# Altium schematic sheet switch.SchDoc
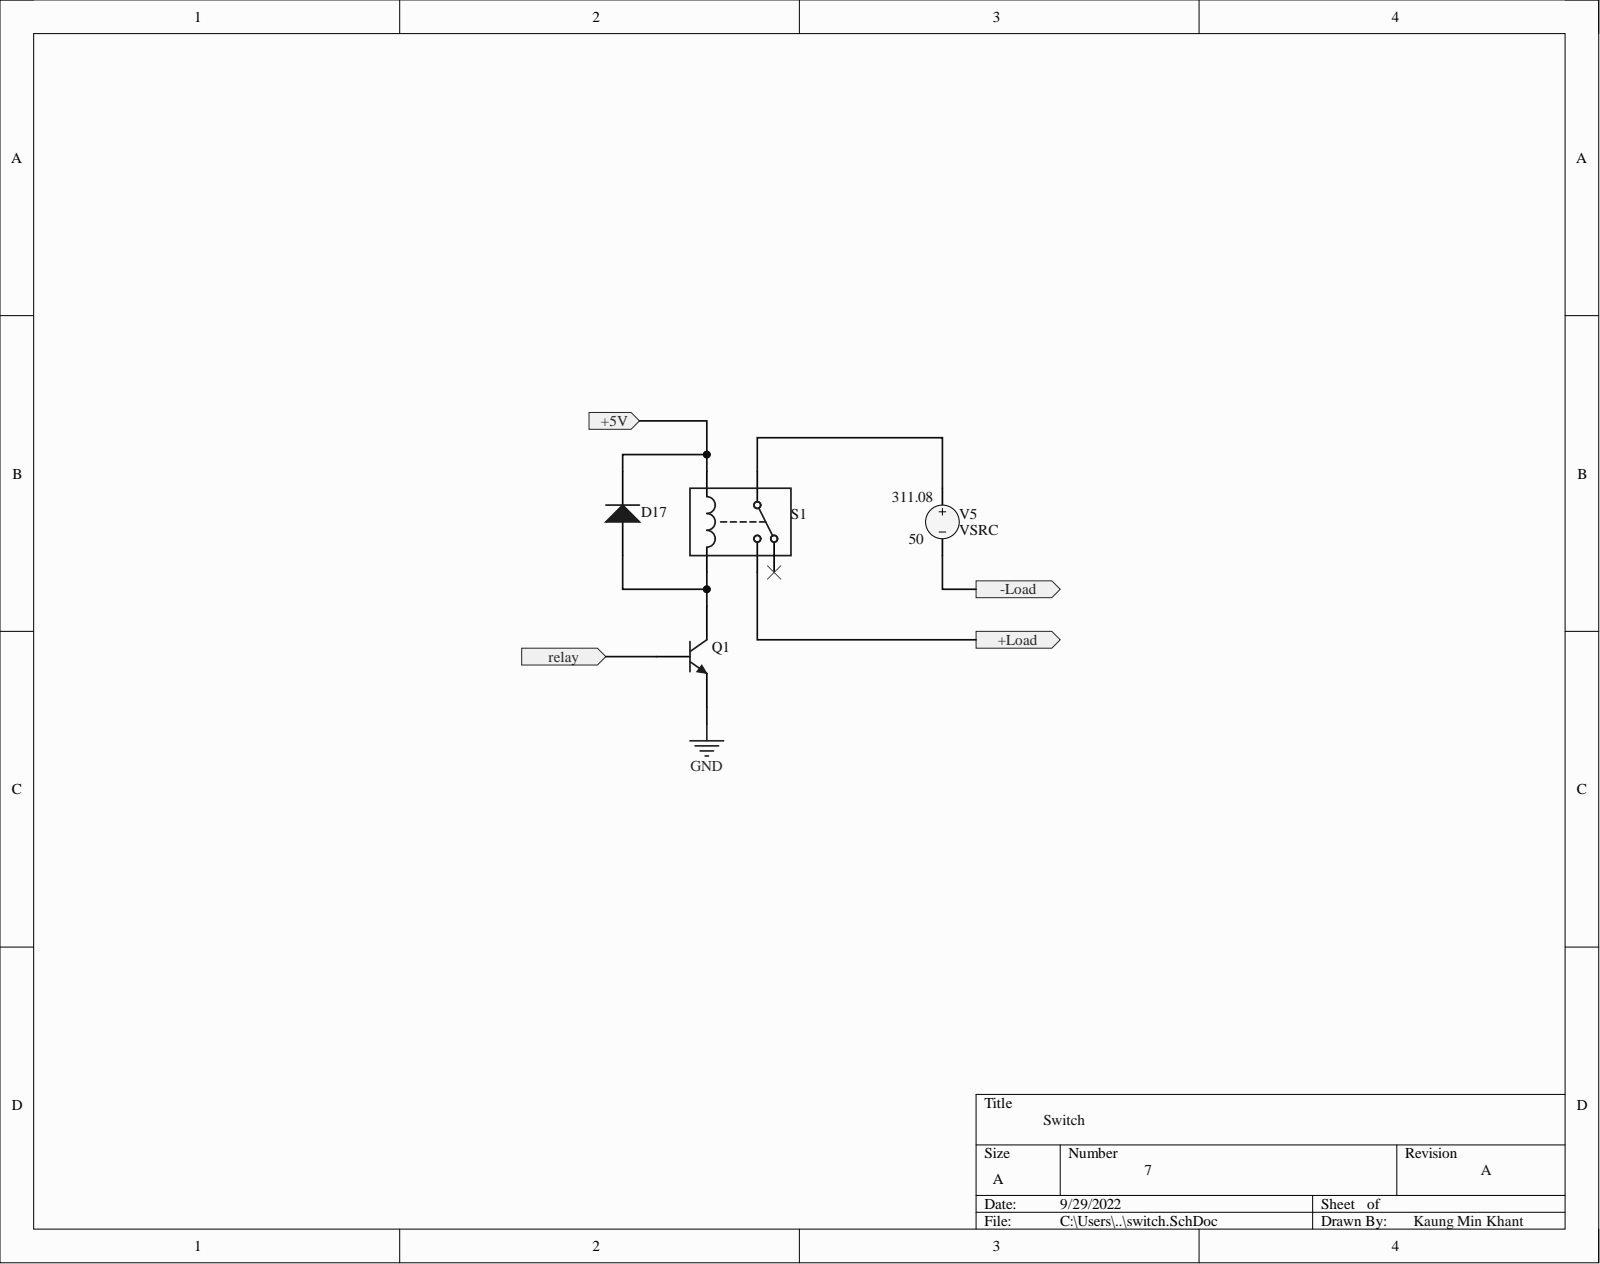
[diagram p0: switch.SchDoc]

## Parts
D17  Diode  (value not drawn)
Q1  Bipolar  NPN 3 Pins  Transistor VBIC Model  (value not drawn)
S1  Single-Pole, Double-Throw Relay  (value not drawn)
V5  VSRC  Amplitude: 311.08

## Ports
+5V, +Load, -Load, relay

## Nets
GND: Q1.3
+5V: D17.2 S1.4 ; port +5V
relay: Q1.2 ; port relay
NetD17_1: D17.1 Q1.1 S1.5
NetS1_1: S1.1 V5.1
NetS1_2: S1.2
NetV5_2: V5.2

Not connected: S1 3

## Library data of the parts
D17 = Diode: AF: 1; BV: 0; BV_MAX: 1e99; EG: 1.11; FC: 0.5; IBV: 0.001; IS: 1e-14; MJSW: 0.33; NTUN: 30; SimulationGenericComponent: True; TNOM: 27; XTI: 3; CJO: 0; CJP: 0; Component Kind: Standard; CTA: 0; CTP: 0; Description: Diode; FCS: 0.5; FV_MAX: 1e99; IKF: 0; IKR: 0; ISR: 1e-14; JSW: 0; JTUN: 0; JTUNSW: 0; KEG: 1; KF: 0; LEVEL: 1; Library Name: Simulation Generic Components.IntLib; N: 1; NBV: 1; NR: 1; NS: 1; PHP: 1; RS: 0; Simulation: diode; TCV: 0; TLEV: 0; TLEVC: 0; TM1: 0; TM2: 0; TPB: 0; TPHP: 0; TRS: 0; TRS2: 0; TT: 0; TTT1: 0; TTT2: 0; VJ: 1; XTITUN: 3
Q1 = BJT NPN VBIC: AFN: 1; AJC: -0.5; ART: 0.1; AVC1: 0; EA: 1.12; FC: 0.9; IBBE: 1e-06; IBCI: 1e-16; IBEI: 1e-18; MC: 0.33; NCN: 2; NKF: 0.5; PC: 0.75; SimulationGenericComponent: True; TNOM: 27; VERS: 1.2; XII: 3; AJE: -0.5; AJS: -0.5; AVC2: 0; BFN: 1; CBCO: 0; CBEO: 0; CCSO: 0; CJC: 0; CJCP: 0; CJE: 0; CJEP: 0; Component Kind: Standard; CTH: 0; DEAR: 0; Description: Bipolar  NPN 3 Pins  Transistor VBIC Model; EAIC: 1.12; EAIE: 1.12; EAIS: 1.12; EANC: 1.12; EANE: 1.12; EANS: 1.12; EAP: 1.12; EBBE: 0; GAMM: 0; HRCF: 1; IBCIP: 0; IBCN: 0; IBCNP: 0; IBEIP: 0; IBEN: 0; IBENP: 0; IKF: 0; IKP: 0; IKR: 0; IS: 1e-16; ISP: 0; ISRR: 1; ITF: 0; KFN: 0; Library Name: Simulation Generic Components.IntLib; ME: 0.33; MS: 0.33; NBBE: 1; NCI: 1; NCIP: 1; NCNP: 2; NEI: 1; NEN: 2; NF: 1; NFP: 1; NR: 1; PE: 0.75; PS: 0.75; QBM: 0; QCO: 0; QTF: 0; RBI: 0.1; RBP: 0.1; RBX: 0; RCI: 0.1; RCX: 0; RE: 0; RS: 0; RTH: 0; Simulation: NPN_VBIC; TAVC: 0; TD: 0; TF: 0; TNBBE: 0; TNF: 0; TR: 0; TVBBE1: 0; TVBBE2: 0; VBBE: 0; VEF: 0; VER: 0; VO: 0; VREF: 0; VRT: 0; VTF: 0; WBE: 1; WSP: 1; XIKF: 0; XIN: 3; XIS: 3; XISR: 0; XRBI: 0; XRBP: 0; XRBX: 0; XRCI: 0; XRCX: 0; XRE: 0; XRS: 0; XTF: 0; XVO: 0
S1 = SPDT Relay: IOFF: 0; ION: 1m; L_C: 1u; R_C: 100; ROFF: 1e12; RON: 1; SimulationGenericComponent: True; Component Kind: Standard; Description: Single-Pole, Double-Throw Relay; Library Name: Simulation Generic Components.IntLib; Simulation: SPDT_RELAY
V5 = VSRC: Voltage Source; AC Magnitude: 1; AC Phase: 0; DC Magnitude: 5; Frequency: 50; Note: PCB Footprint - Not required; Component Kind: Standard; Damping Factor: 0; Delay: 0; LatestRevisionDate: 30-July-2020; LatestRevisionNote: Re-released for DXP Platform.; Library Name: Simulation Generic Components.IntLib; Offset: 0; PackageReference: Not Applicable; Phase: 0; Published: 30-July-2020; Simulation: VSRC; Publisher: Altium Limited
